# Altium schematic sheet LoRa Radio.SchDoc("LoRa Radio")
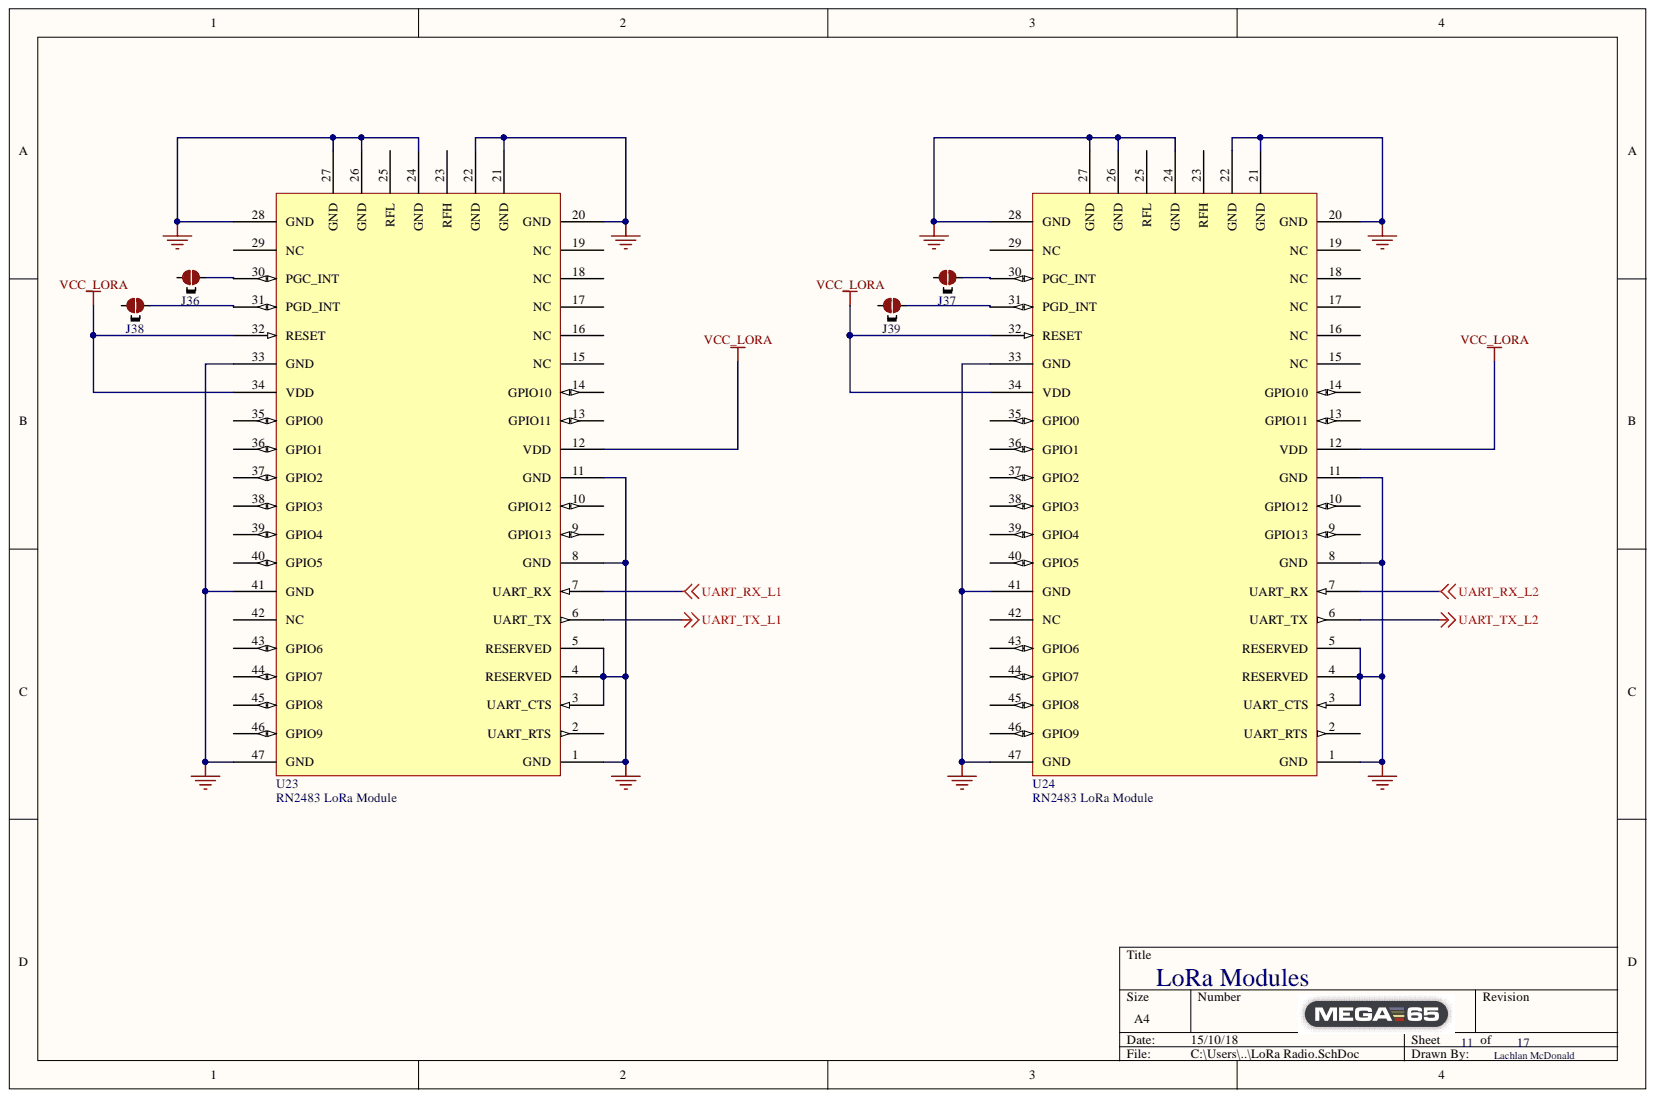
[diagram p0: LoRa Radio.SchDoc]

## Parts
J36  2 way net solder junction - Normally Connected  [2waySolder_NC]  (value not drawn)  (no BOM)
J37  2 way net solder junction - Normally Connected  [2waySolder_NC]  (value not drawn)  (no BOM)
J38  2 way net solder junction - Normally Connected  [2waySolder_NC]  (value not drawn)  (no BOM)
J39  2 way net solder junction - Normally Connected  [2waySolder_NC]  (value not drawn)  (no BOM)
U23  RN2483 LoRa Module  [MICROCHIP_RN2483-I/RM095]
U24  RN2483 LoRa Module  [MICROCHIP_RN2483-I/RM095]

## Nets
GND: U23.1(GND) U23.3(UART_CTS) U23.4(RESERVED) U23.5(RESERVED) U23.8(GND) U23.11(GND) U23.20(GND) U23.21(GND) U23.22(GND) U23.24(GND) U23.26(GND) U23.27(GND) U23.28(GND) U23.33(GND) U23.41(GND) U23.47(GND) U24.1(GND) U24.3(UART_CTS) U24.4(RESERVED) U24.5(RESERVED) U24.8(GND) U24.11(GND) U24.20(GND) U24.21(GND) U24.22(GND) U24.24(GND) U24.26(GND) U24.27(GND) U24.28(GND) U24.33(GND) U24.41(GND) U24.47(GND)
UART_RX_L1: U23.7(UART_RX)
UART_RX_L2: U24.7(UART_RX)
UART_TX_L1: U23.6(UART_TX)
UART_TX_L2: U24.6(UART_TX)
VCC_LORA: U23.12(VDD) U23.32(RESET) U23.34(VDD) U24.12(VDD) U24.32(RESET) U24.34(VDD)
NetJ36_2: J36.2 U23.30(PGC_INT)
NetJ37_2: J37.2 U24.30(PGC_INT)
NetJ38_2: J38.2 U23.31(PGD_INT)
NetJ39_2: J39.2 U24.31(PGD_INT)

Not connected: J36 1; J37 1; J38 1; J39 1; U23 2(UART_RTS) 9(GPIO13) 10(GPIO12) 13(GPIO11) 14(GPIO10) 15(NC) 16(NC) 17(NC) 18(NC) 19(NC) 23(RFH) 25(RFL) 29(NC) 35(GPIO0) 36(GPIO1) 37(GPIO2) 38(GPIO3) 39(GPIO4) 40(GPIO5) 42(NC) 43(GPIO6) 44(GPIO7) 45(GPIO8) 46(GPIO9); U24 2(UART_RTS) 9(GPIO13) 10(GPIO12) 13(GPIO11) 14(GPIO10) 15(NC) 16(NC) 17(NC) 18(NC) 19(NC) 23(RFH) 25(RFL) 29(NC) 35(GPIO0) 36(GPIO1) 37(GPIO2) 38(GPIO3) 39(GPIO4) 40(GPIO5) 42(NC) 43(GPIO6) 44(GPIO7) 45(GPIO8) 46(GPIO9)

## Library data of the parts
J36-J39 = 2waySolderNC: Library Name: NetTieLib.IntLib; Description: 2 way net solder junction - Normally Connected; ComponentLink1URL: http://www.edatools.cz/addons; ComponentLink1Description: Source
U23 U24 = RN2483 LoRa Module: Library Name: Schlib1.SchLib; Component Kind: Standard
